# Altium schematic sheet CANDLE-01.SchDoc(CANDLE-01)
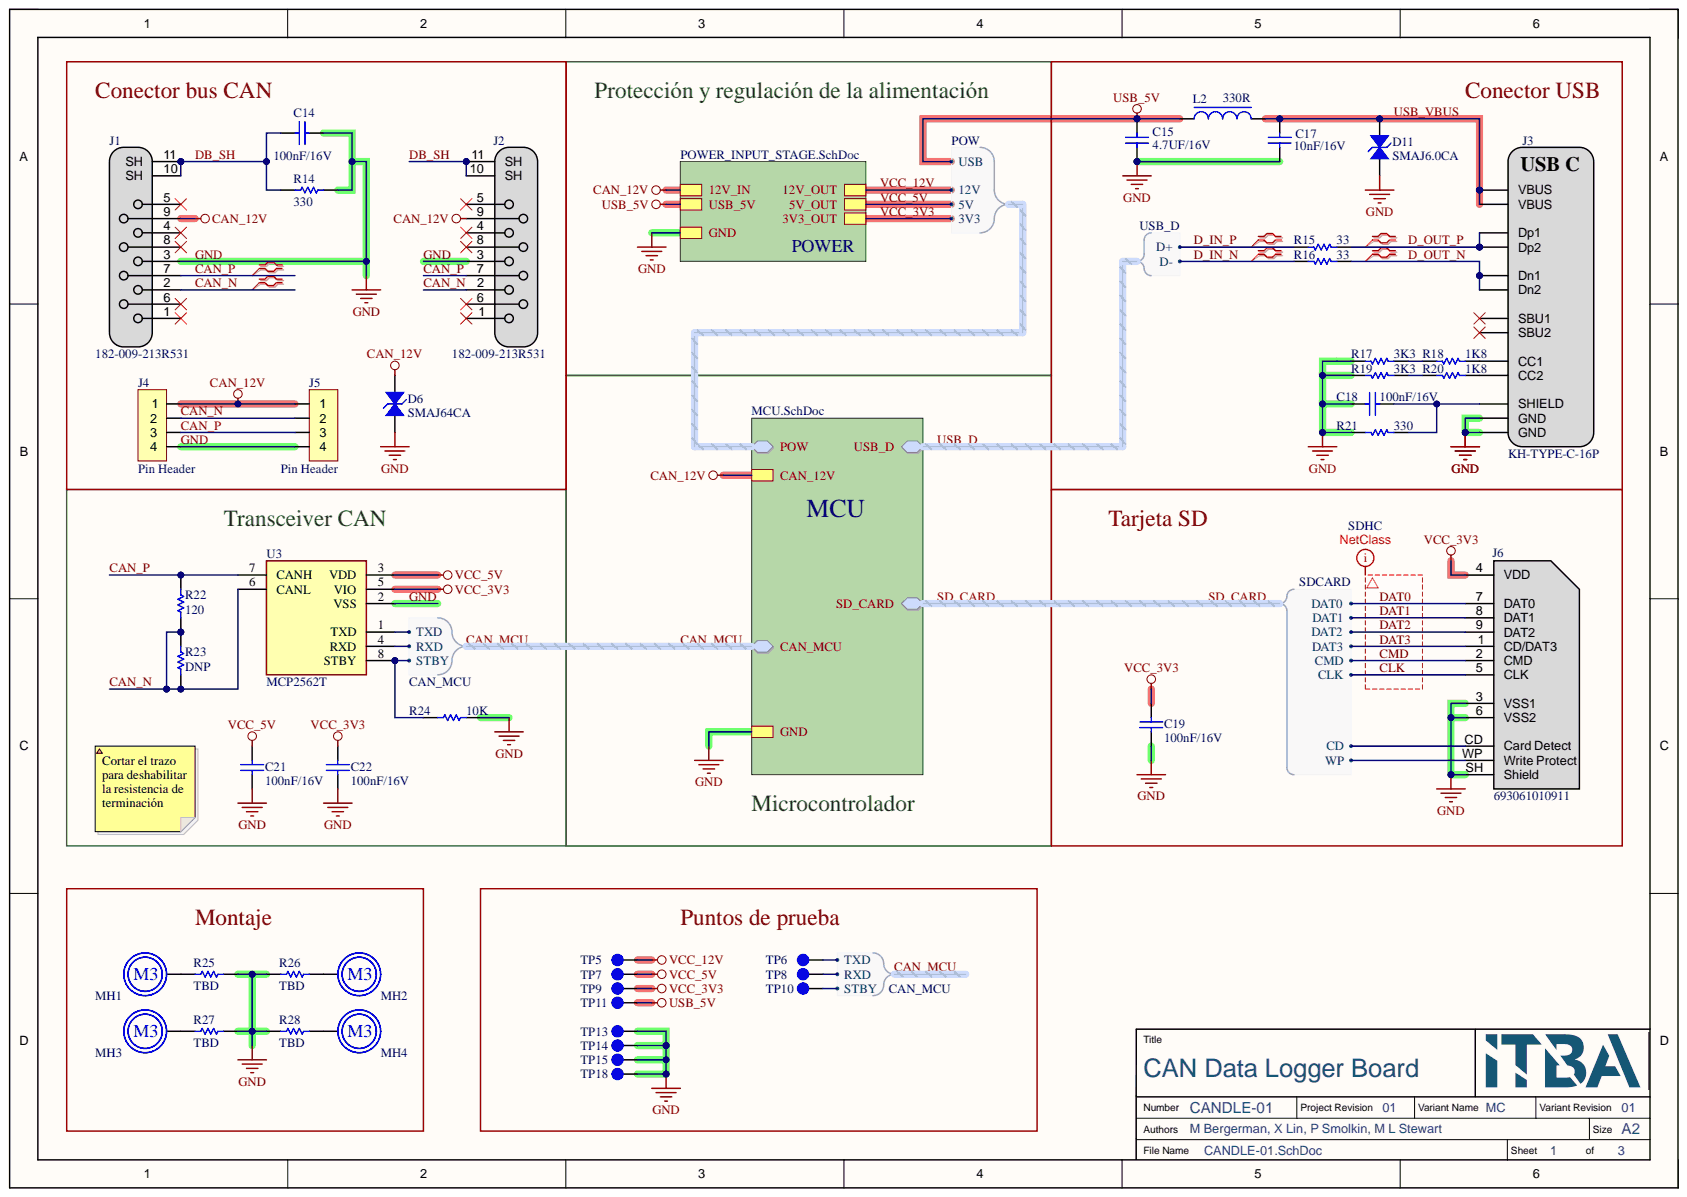
[diagram p0: CANDLE-01.SchDoc]

## Parts
C14  100nF/16V  [CAP0805]
C15  4.7UF/16V  [CAP0805]
C17  10nF/16V  [CAP0805]
C18  100nF/16V  [CAP0805]
C19  100nF/16V  [CAP0805]
C21  100nF/16V  [CAP0805]
C22  100nF/16V  [CAP0805]
D6  SMAJ64CA  [DO-214AC]
D11  SMAJ6.0CA  [DO-214AC]
J1  182-009-213R531  [DE-9 - Female]
J2  182-009-213R531  [DE-9 - Female]
J3  KH-TYPE-C-16P  [USB4105-GF-A-060]
J4  Pin Header  [HEADER-4]
J5  Pin Header  [HEADER-4]
J6  693061010911  [SD CARD CONNECTOR]
L2  330R  [RES0805]
MH1  M3 Mount Hole  [M3_MOUNTING_HOLE]  (value not drawn)  (no BOM)
MH2  M3 Mount Hole  [M3_MOUNTING_HOLE]  (value not drawn)  (no BOM)
MH3  M3 Mount Hole  [M3_MOUNTING_HOLE]  (value not drawn)  (no BOM)
MH4  M3 Mount Hole  [M3_MOUNTING_HOLE]  (value not drawn)  (no BOM)
R14  330  [RES0805]
R15  33  [RES0805]
R16  33  [RES0805]
R17  3K3  [RES0805]
R18  1K8  [RES0805]
R19  3K3  [RES0805]
R20  1K8  [RES0805]
R21  330  [RES0805]
R22  120  [RES0805]
R23  DNP  [RES0805]  (no BOM)
R24  10K  [RES0805]
R25  TBD  [RES0805]
R26  TBD  [RES0805]
R27  TBD  [RES0805]
R28  TBD  [RES0805]
TP5  Testpoint  [TP_SMD_Ø1.0mm]  (value not drawn)  (no BOM)
TP6  Testpoint  [TP_SMD_Ø1.0mm]  (value not drawn)  (no BOM)
TP7  Testpoint  [TP_SMD_Ø1.0mm]  (value not drawn)  (no BOM)
TP8  Testpoint  [TP_SMD_Ø1.0mm]  (value not drawn)  (no BOM)
TP9  Testpoint  [TP_SMD_Ø1.0mm]  (value not drawn)  (no BOM)
TP10  Testpoint  [TP_SMD_Ø1.0mm]  (value not drawn)  (no BOM)
TP11  Testpoint  [TP_SMD_Ø1.0mm]  (value not drawn)  (no BOM)
TP13  Testpoint  [TP_SMD_Ø1.0mm]  (value not drawn)  (no BOM)
TP14  Testpoint  [TP_SMD_Ø1.0mm]  (value not drawn)  (no BOM)
TP15  Testpoint  [TP_SMD_Ø1.0mm]  (value not drawn)  (no BOM)
TP18  Testpoint  [TP_SMD_Ø1.0mm]  (value not drawn)  (no BOM)
U3  MCP2562T  [8-SOIC]

## Nets
CAN_12V: D6.2 J1.9 J2.9 J4.1 J5.1
USB_5V: C15.2 L2.1 TP11.1
CAN_MCU.RXD: TP8.1 U3.4(RXD)
CAN_MCU.STBY: R24.2 TP10.1 U3.8(STBY)
CAN_MCU.TXD: TP6.1 U3.1(TXD)
CAN_N: J1.2 J2.2 J4.2 J5.2 R22.1 R23.1 R23.2 U3.6(CANL)
CAN_P: J1.7 J2.7 J4.3 J5.3 R22.2 U3.7(CANH)
CLK: J6.5(CLK)
CMD: J6.2(CMD)
D_IN_N: R16.1
D_IN_P: R15.1
D_OUT_N: J3.A7(Dn1) J3.B7(Dn2) R16.2
D_OUT_P: J3.A6(Dp1) J3.B6(Dp2) R15.2
DAT0: J6.7(DAT0)
DAT1: J6.8(DAT1)
DAT2: J6.9(DAT2)
DAT3: J6.1(CD/DAT3)
DB_SH: C14.1 J1.10(SH) J1.11(SH) J2.10(SH) J2.11(SH) R14.1
GND: C14.2 C15.1 C17.1 C18.2 C19.1 C21.1 C22.1 D6.1 D11.1 J1.3 J2.3 J3.A1B12(GND) J3.B1A12(GND) J4.4 J5.4 J6.3(VSS1) J6.6(VSS2) J6.SH(Shield) R14.2 R17.1 R19.1 R21.2 R24.1 R25.1 R26.2 R27.1 R28.2 TP13.1 TP14.1 TP15.1 TP18.1 U3.2(VSS)
SD_CARD.CD: J6.CD(Card_Detect)
SD_CARD.WP: J6.WP(Write_Protect)
USB_VBUS: C17.2 D11.2 J3.A4B9(VBUS) J3.B4A9(VBUS) L2.2
VCC_3V3: C19.2 C22.2 J6.4(VDD) TP9.1 U3.5(VIO)
VCC_5V: C21.2 TP7.1 U3.3(VDD)
VCC_12V: TP5.1
NetC18_1: C18.1 J3.SH(SHIELD) R21.1
NetJ1_1: J1.1
NetJ1_4: J1.4
NetJ1_5: J1.5
NetJ1_6: J1.6
NetJ1_8: J1.8
NetJ2_1: J2.1
NetJ2_4: J2.4
NetJ2_5: J2.5
NetJ2_6: J2.6
NetJ2_8: J2.8
NetJ3_A5: J3.A5(CC1) R18.2
NetJ3_A8: J3.A8(SBU1)
NetJ3_B5: J3.B5(CC2) R20.2
NetJ3_B8: J3.B8(SBU2)
NetMH1_1: MH1.1 R25.2
NetMH2_1: MH2.1 R26.1
NetMH3_1: MH3.1 R27.2
NetMH4_1: MH4.1 R28.1
NetR17_2: R17.2 R18.1
NetR19_2: R19.2 R20.1

## Library data of the parts
C14 C15 C17-C19 C21 C22 = Capacitor: SMD 0805 Capacitor; Component Kind: Standard; Library Name: CANDLE-LIB.SchLib
D6 D11 = Diode TVS: TVS Diode; Component Kind: Standard; Library Name: CANDLE-LIB.SchLib
J1 J2 = DE-09: Receptacle Assembly, 9 Position, Right Angle; Component Kind: Standard; Library Name: CANDLE-LIB.SchLib
J3 = USB4105-GF-A-060: USB Connector Type C SMT 12 Pin; Manufacturer: GCT; Component Kind: Standard; Distributor Link: https://eu.mouser.com/ProductDetail/GCT/USB4105-GF-A-060?qs=QNEnbhJQKvY2mocwNJzgfA%3D%3D; Manufacturer Part Number: USB4105-GF-A-060; Library Name: CANDLE-LIB.SchLib
J4 J5 = HEADER-4: Pin Header 4-pos; Component Kind: Standard; Library Name: CANDLE-LIB.SchLib
J6 = SD_CARD_READER: SD Card Reader; Component Kind: Standard; Library Name: CANDLE-LIB.SchLib
L2 = Ferrite Bead: Component Kind: Standard; Description: Ferrite Bead; Library Name: CANDLE-LIB.SchLib
MH1-MH4 = M3 Mount Hole: M3 Mounting Hole; Library Name: CANDLE-LIB.SchLib
R14-R28 = Resistor: SMD 0805 Resistor; Library Name: CANDLE-LIB.SchLib
  R14-R22 R24-R28: Component Kind: Standard
U3 = MCP2562T: High-Speed CAN Transceiver; Component Kind: Standard; Library Name: CANDLE-LIB.SchLib
TP5-TP11 TP13-TP15 TP18 = Testpoint: Description: Testpoint; Library Name: CANDLE-LIB.SchLib
